# Altium schematic sheet retroBreadboard_v1i1.SchDoc
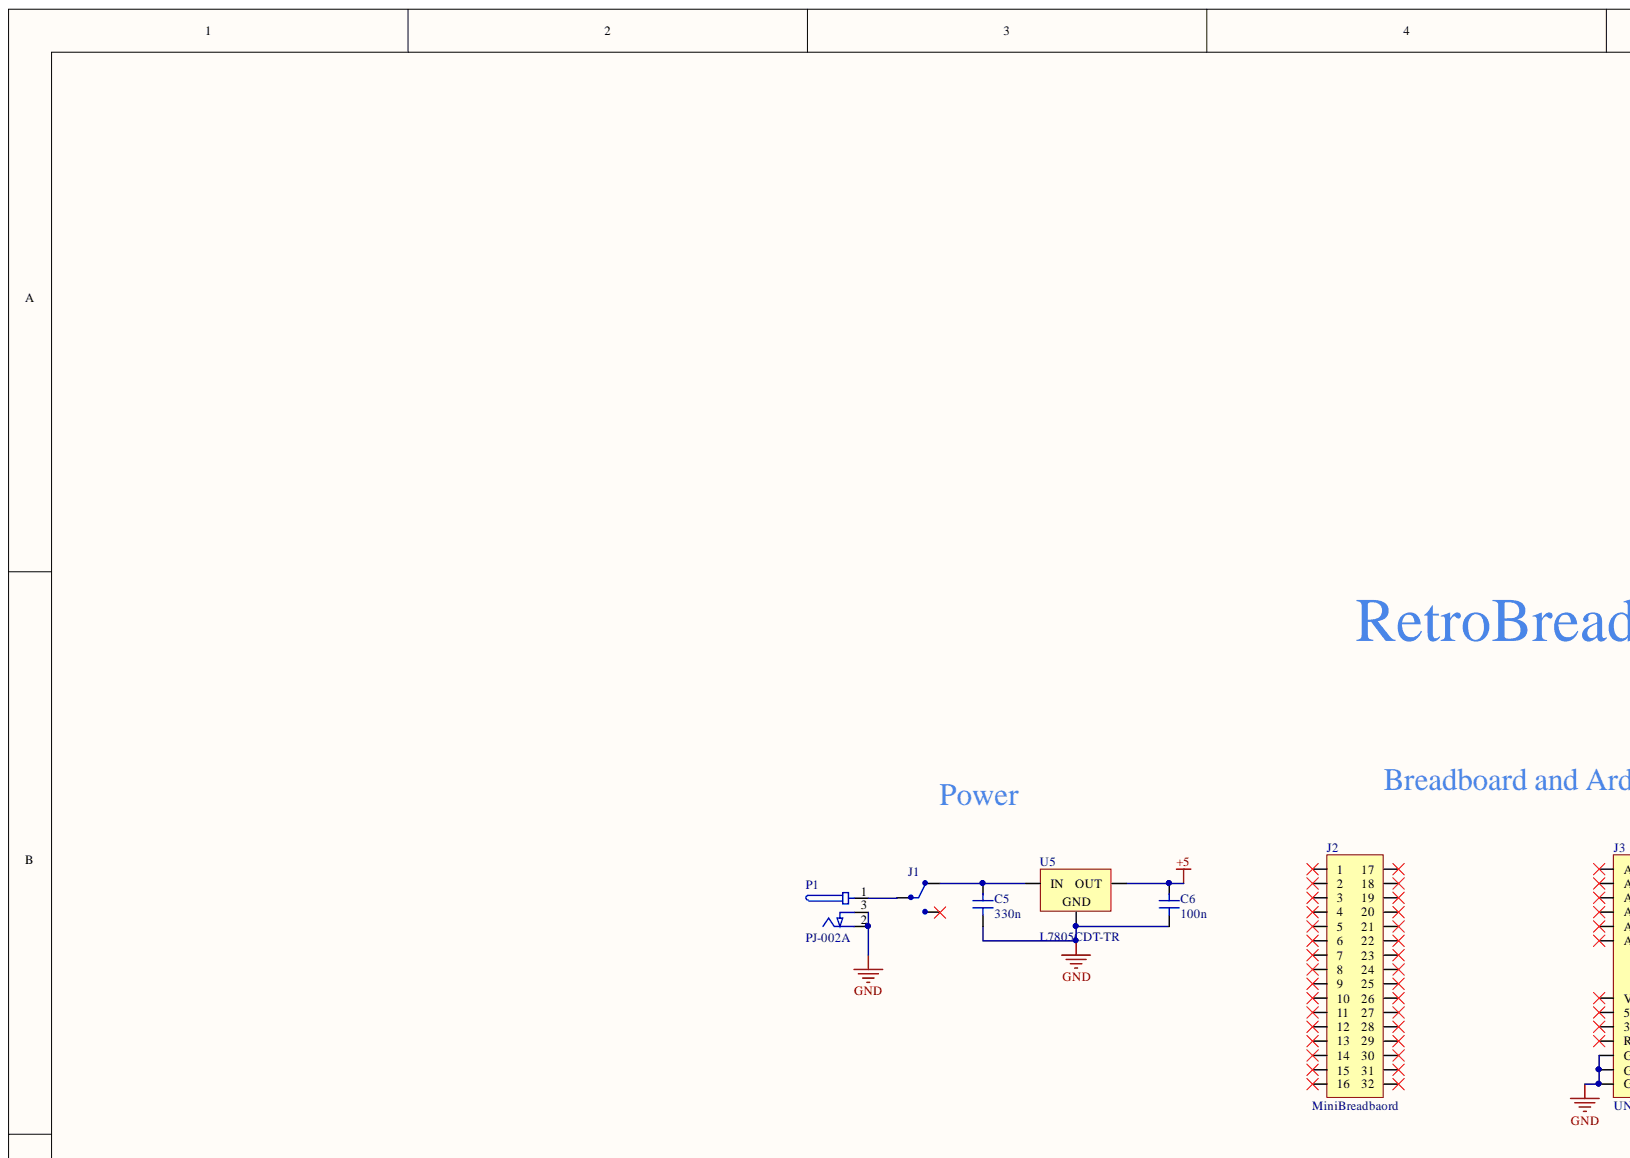
[diagram p0: retroBreadboard_v1i1.SchDoc, top left]
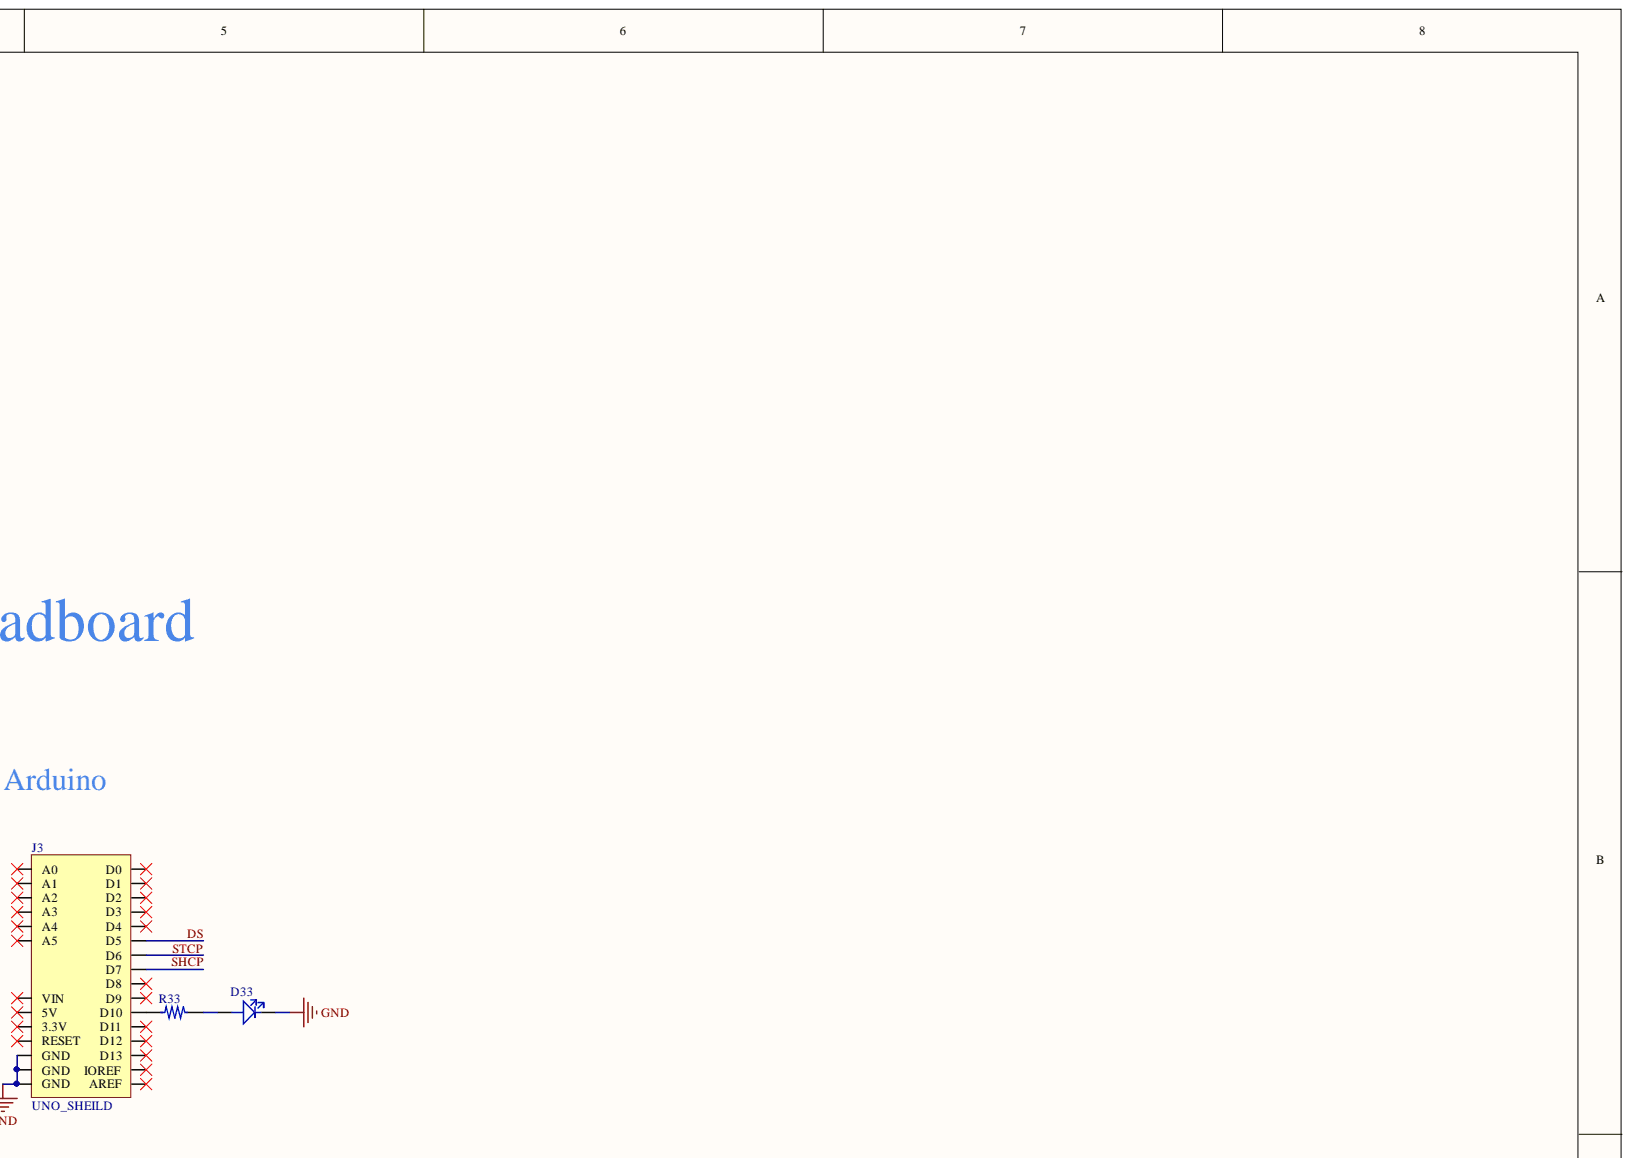
[diagram p1: retroBreadboard_v1i1.SchDoc, top right]
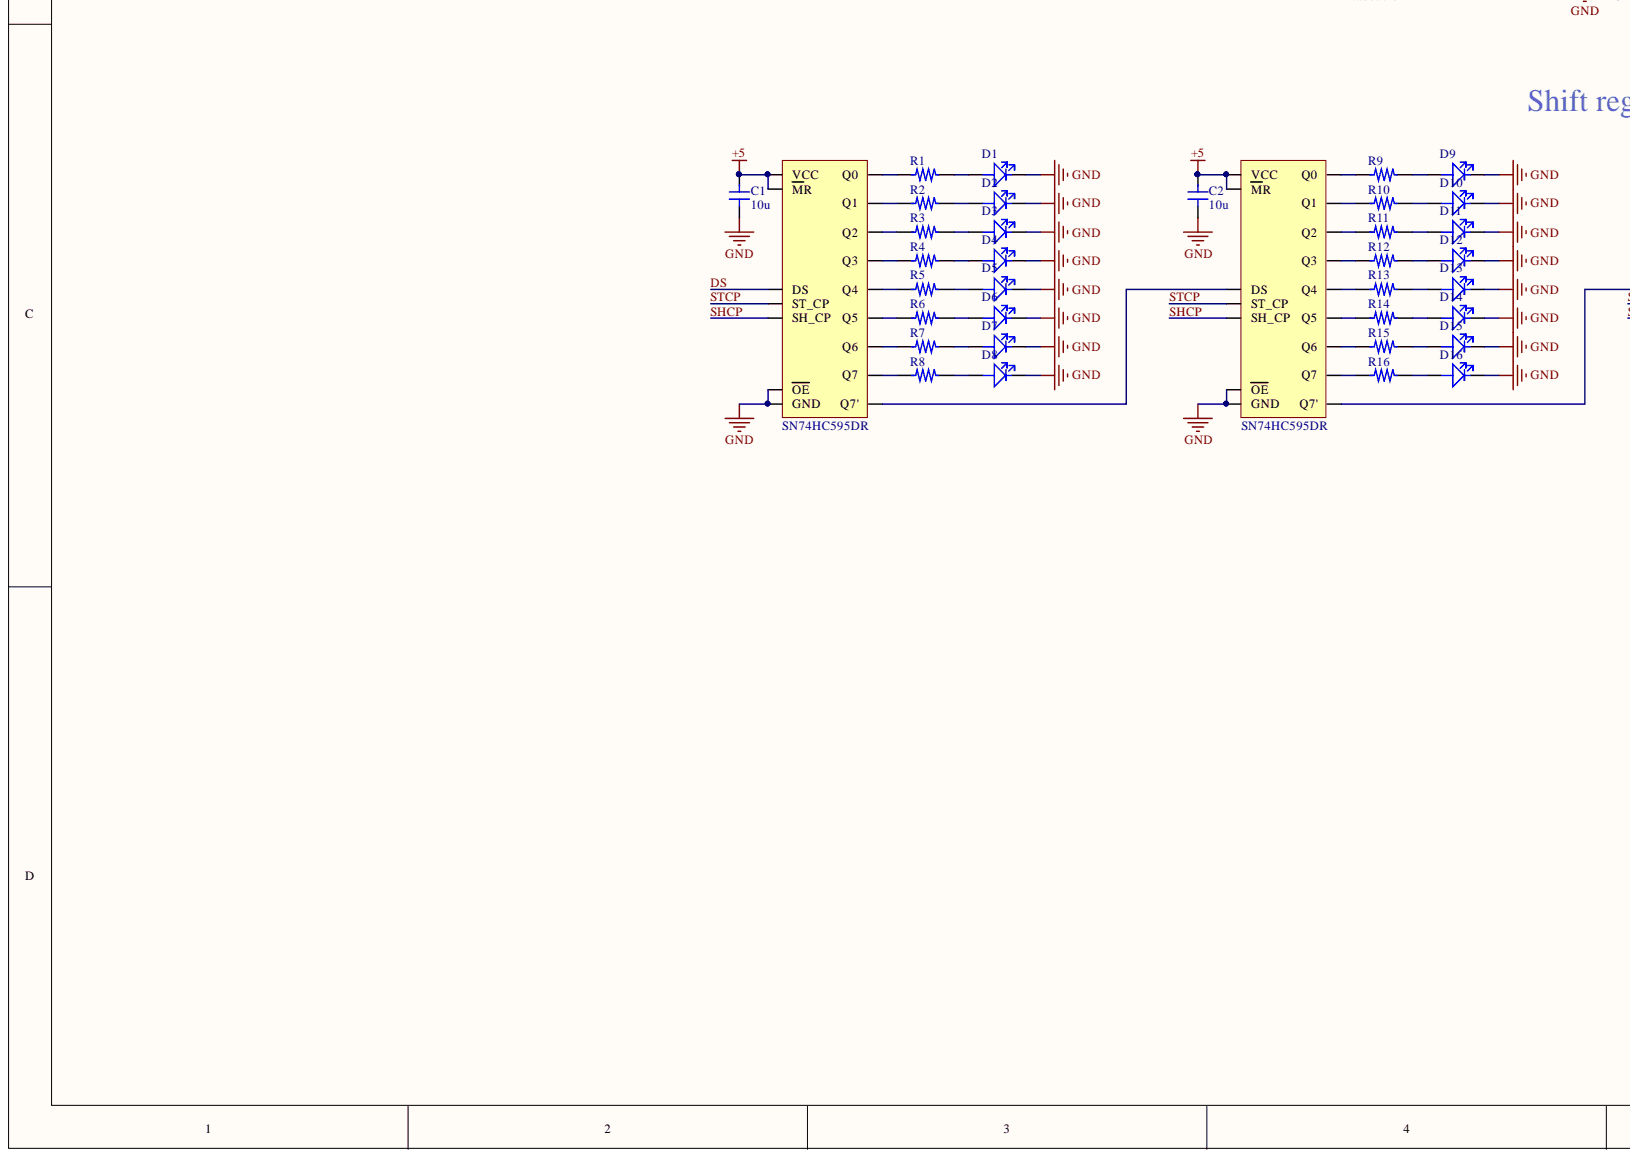
[diagram p2: retroBreadboard_v1i1.SchDoc, bottom left]
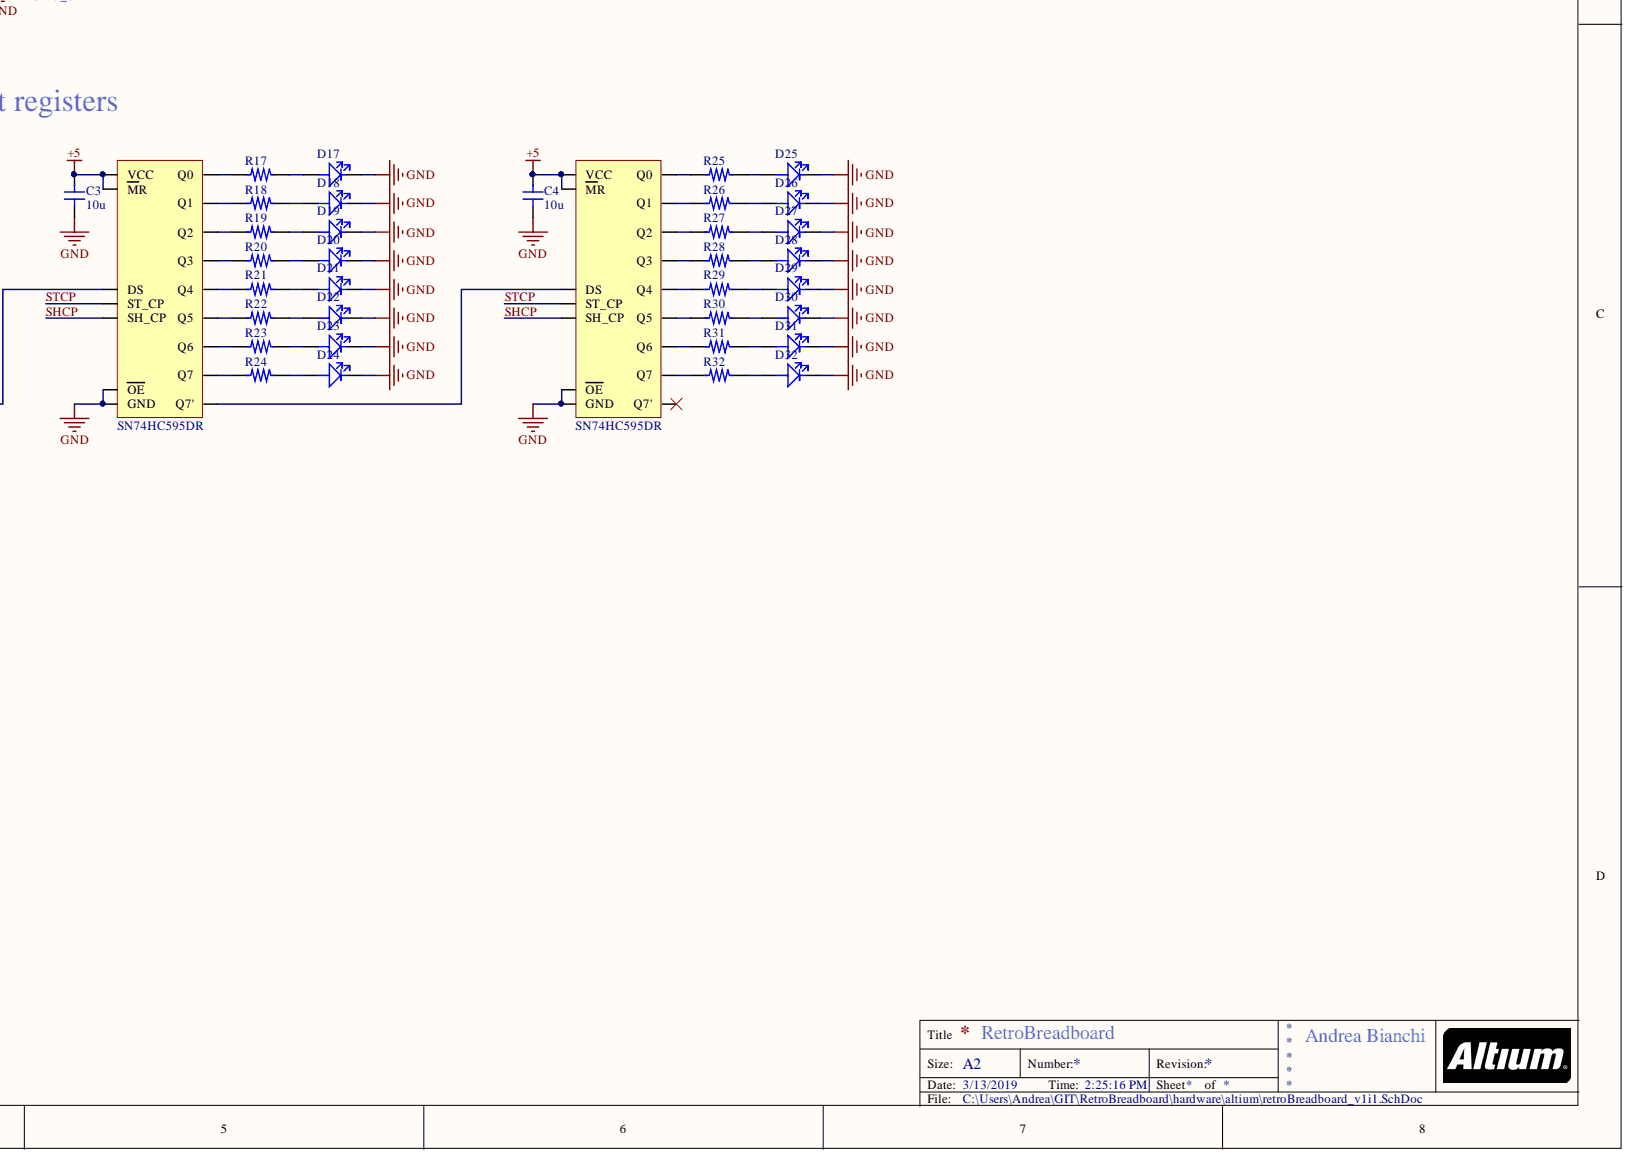
[diagram p3: retroBreadboard_v1i1.SchDoc, bottom right]

## Parts
C1  10u  [C0805]
C2  10u  [C0805]
C3  10u  [C0805]
C4  10u  [C0805]
C5  330n  [C0805]
C6  100n  [C0805]
D1  VLMB1500-GS08  [VLMB1500-GS08]  (value not drawn)
D2  VLMB1500-GS08  [VLMB1500-GS08]  (value not drawn)
D3  VLMB1500-GS08  [VLMB1500-GS08]  (value not drawn)
D4  VLMB1500-GS08  [VLMB1500-GS08]  (value not drawn)
D5  VLMB1500-GS08  [VLMB1500-GS08]  (value not drawn)
D6  VLMB1500-GS08  [VLMB1500-GS08]  (value not drawn)
D7  VLMB1500-GS08  [VLMB1500-GS08]  (value not drawn)
D8  VLMB1500-GS08  [VLMB1500-GS08]  (value not drawn)
D9  VLMB1500-GS08  [VLMB1500-GS08]  (value not drawn)
D10  VLMB1500-GS08  [VLMB1500-GS08]  (value not drawn)
D11  VLMB1500-GS08  [VLMB1500-GS08]  (value not drawn)
D12  VLMB1500-GS08  [VLMB1500-GS08]  (value not drawn)
D13  VLMB1500-GS08  [VLMB1500-GS08]  (value not drawn)
D14  VLMB1500-GS08  [VLMB1500-GS08]  (value not drawn)
D15  VLMB1500-GS08  [VLMB1500-GS08]  (value not drawn)
D16  VLMB1500-GS08  [VLMB1500-GS08]  (value not drawn)
D17  VLMB1500-GS08  [VLMB1500-GS08]  (value not drawn)
D18  VLMB1500-GS08  [VLMB1500-GS08]  (value not drawn)
D19  VLMB1500-GS08  [VLMB1500-GS08]  (value not drawn)
D20  VLMB1500-GS08  [VLMB1500-GS08]  (value not drawn)
D21  VLMB1500-GS08  [VLMB1500-GS08]  (value not drawn)
D22  VLMB1500-GS08  [VLMB1500-GS08]  (value not drawn)
D23  VLMB1500-GS08  [VLMB1500-GS08]  (value not drawn)
D24  VLMB1500-GS08  [VLMB1500-GS08]  (value not drawn)
D25  VLMB1500-GS08  [VLMB1500-GS08]  (value not drawn)
D26  VLMB1500-GS08  [VLMB1500-GS08]  (value not drawn)
D27  VLMB1500-GS08  [VLMB1500-GS08]  (value not drawn)
D28  VLMB1500-GS08  [VLMB1500-GS08]  (value not drawn)
D29  VLMB1500-GS08  [VLMB1500-GS08]  (value not drawn)
D30  VLMB1500-GS08  [VLMB1500-GS08]  (value not drawn)
D31  VLMB1500-GS08  [VLMB1500-GS08]  (value not drawn)
D32  VLMB1500-GS08  [VLMB1500-GS08]  (value not drawn)
D33  VLMB1500-GS08  [VLMB1500-GS08]  (value not drawn)
J1  500SSP1S1M6QEA  [SLIDE SPDT]  (value not drawn)
J2  MiniBreadbaord  [MINI_BREADBOARD HOLE]
J3  UNO_SHEILD  [ARDUINO_UNO_SHIELD]
P1  PJ-002A  [PJ-002A]
R1  RC0402FR-07200RL  [RES0402]  (value not drawn)
R2  RC0402FR-07200RL  [RES0402]  (value not drawn)
R3  RC0402FR-07200RL  [RES0402]  (value not drawn)
R4  RC0402FR-07200RL  [RES0402]  (value not drawn)
R5  RC0402FR-07200RL  [RES0402]  (value not drawn)
R6  RC0402FR-07200RL  [RES0402]  (value not drawn)
R7  RC0402FR-07200RL  [RES0402]  (value not drawn)
R8  RC0402FR-07200RL  [RES0402]  (value not drawn)
R9  RC0402FR-07200RL  [RES0402]  (value not drawn)
R10  RC0402FR-07200RL  [RES0402]  (value not drawn)
R11  RC0402FR-07200RL  [RES0402]  (value not drawn)
R12  RC0402FR-07200RL  [RES0402]  (value not drawn)
R13  RC0402FR-07200RL  [RES0402]  (value not drawn)
R14  RC0402FR-07200RL  [RES0402]  (value not drawn)
R15  RC0402FR-07200RL  [RES0402]  (value not drawn)
R16  RC0402FR-07200RL  [RES0402]  (value not drawn)
R17  RC0402FR-07200RL  [RES0402]  (value not drawn)
R18  RC0402FR-07200RL  [RES0402]  (value not drawn)
R19  RC0402FR-07200RL  [RES0402]  (value not drawn)
R20  RC0402FR-07200RL  [RES0402]  (value not drawn)
R21  RC0402FR-07200RL  [RES0402]  (value not drawn)
R22  RC0402FR-07200RL  [RES0402]  (value not drawn)
R23  RC0402FR-07200RL  [RES0402]  (value not drawn)
R24  RC0402FR-07200RL  [RES0402]  (value not drawn)
R25  RC0402FR-07200RL  [RES0402]  (value not drawn)
R26  RC0402FR-07200RL  [RES0402]  (value not drawn)
R27  RC0402FR-07200RL  [RES0402]  (value not drawn)
R28  RC0402FR-07200RL  [RES0402]  (value not drawn)
R29  RC0402FR-07200RL  [RES0402]  (value not drawn)
R30  RC0402FR-07200RL  [RES0402]  (value not drawn)
R31  RC0402FR-07200RL  [RES0402]  (value not drawn)
R32  RC0402FR-07200RL  [RES0402]  (value not drawn)
R33  RC0402FR-07200RL  [RES0402]  (value not drawn)
U1  SN74HC595DR  [SOIC16]
U2  SN74HC595DR  [SOIC16]
U3  SN74HC595DR  [SOIC16]
U4  SN74HC595DR  [SOIC16]
U5  L7805CDT-TR  [DPAK]

## Nets
+5: C1.2 C2.2 C3.2 C4.2 C6.2 U1.10(MR) U1.16(VCC) U2.10(MR) U2.16(VCC) U3.10(MR) U3.16(VCC) U4.10(MR) U4.16(VCC) U5.3
GND: C1.1 C2.1 C3.1 C4.1 C5.1 C6.1 D1.2 D2.2 D3.2 D4.2 D5.2 D6.2 D7.2 D8.2 D9.2 D10.2 D11.2 D12.2 D13.2 D14.2 D15.2 D16.2 D17.2 D18.2 D19.2 D20.2 D21.2 D22.2 D23.2 D24.2 D25.2 D26.2 D27.2 D28.2 D29.2 D30.2 D31.2 D32.2 D33.2 J3.15(GND) J3.24(GND) J3.25(GND) P1.2 P1.3 U1.8(GND) U1.13(OE) U2.8(GND) U2.13(OE) U3.8(GND) U3.13(OE) U4.8(GND) U4.13(OE) U5.4
DS: J3.6(D5) U1.14(DS)
SHCP: J3.8(D7) U1.11(SH_CP) U2.11(SH_CP) U3.11(SH_CP) U4.11(SH_CP)
STCP: J3.7(D6) U1.12(ST_CP) U2.12(ST_CP) U3.12(ST_CP) U4.12(ST_CP)
NetC5_2: C5.2 J1.1 U5.1
NetD1_1: D1.1 R1.2
NetD2_1: D2.1 R2.2
NetD3_1: D3.1 R3.2
NetD4_1: D4.1 R4.2
NetD5_1: D5.1 R5.2
NetD6_1: D6.1 R6.2
NetD7_1: D7.1 R7.2
NetD8_1: D8.1 R8.2
NetD9_1: D9.1 R9.2
NetD10_1: D10.1 R10.2
NetD11_1: D11.1 R11.2
NetD12_1: D12.1 R12.2
NetD13_1: D13.1 R13.2
NetD14_1: D14.1 R14.2
NetD15_1: D15.1 R15.2
NetD16_1: D16.1 R16.2
NetD17_1: D17.1 R17.2
NetD18_1: D18.1 R18.2
NetD19_1: D19.1 R19.2
NetD20_1: D20.1 R20.2
NetD21_1: D21.1 R21.2
NetD22_1: D22.1 R22.2
NetD23_1: D23.1 R23.2
NetD24_1: D24.1 R24.2
NetD25_1: D25.1 R25.2
NetD26_1: D26.1 R26.2
NetD27_1: D27.1 R27.2
NetD28_1: D28.1 R28.2
NetD29_1: D29.1 R29.2
NetD30_1: D30.1 R30.2
NetD31_1: D31.1 R31.2
NetD32_1: D32.1 R32.2
NetD33_1: D33.1 R33.2
NetJ1_2: J1.2 P1.1
NetJ3_11: J3.11(D10) R33.1
NetR1_1: R1.1 U1.15(Q0)
NetR2_1: R2.1 U1.1(Q1)
NetR3_1: R3.1 U1.2(Q2)
NetR4_1: R4.1 U1.3(Q3)
NetR5_1: R5.1 U1.4(Q4)
NetR6_1: R6.1 U1.5(Q5)
NetR7_1: R7.1 U1.6(Q6)
NetR8_1: R8.1 U1.7(Q7)
NetR9_1: R9.1 U2.15(Q0)
NetR10_1: R10.1 U2.1(Q1)
NetR11_1: R11.1 U2.2(Q2)
NetR12_1: R12.1 U2.3(Q3)
NetR13_1: R13.1 U2.4(Q4)
NetR14_1: R14.1 U2.5(Q5)
NetR15_1: R15.1 U2.6(Q6)
NetR16_1: R16.1 U2.7(Q7)
NetR17_1: R17.1 U3.15(Q0)
NetR18_1: R18.1 U3.1(Q1)
NetR19_1: R19.1 U3.2(Q2)
NetR20_1: R20.1 U3.3(Q3)
NetR21_1: R21.1 U3.4(Q4)
NetR22_1: R22.1 U3.5(Q5)
NetR23_1: R23.1 U3.6(Q6)
NetR24_1: R24.1 U3.7(Q7)
NetR25_1: R25.1 U4.15(Q0)
NetR26_1: R26.1 U4.1(Q1)
NetR27_1: R27.1 U4.2(Q2)
NetR28_1: R28.1 U4.3(Q3)
NetR29_1: R29.1 U4.4(Q4)
NetR30_1: R30.1 U4.5(Q5)
NetR31_1: R31.1 U4.6(Q6)
NetR32_1: R32.1 U4.7(Q7)
NetU1_9: U1.9(Q7') U2.14(DS)
NetU2_9: U2.9(Q7') U3.14(DS)
NetU3_9: U3.9(Q7') U4.14(DS)
NetU4_9: U4.9(Q7')

Not connected: J1 3; J2 1 2 3 4 5 6 7 8 9 10 11 12 13 14 15 16 17 18 19 20 21 22 23 24 25 26 27 28 29 30 31 32; J3 1(D0) 2(D1) 3(D2) 4(D3) 5(D4) 9(D8) 10(D9) 12(D11) 13(D12) 14(D13) 16(AREF) 17(A5) 18(A4) 19(A3) 20(A2) 21(A1) 22(A0) 23(VIN) 26(5V) 27(3.3V) 28(RESET) 29(IOREF)

## Library data of the parts
C1-C4 = C0805_10u: Manufacturer: Samsung; Manufacturer Part Number: CL21A106KQFNNNG; Capacitance: 10uF; Dielectric: X5R; Material: Ceramic; Tolerance: 10%; Voltage: 6.3V; Case/Package: 0805; Case Code (Imperial): 0805; Case Code (Metric): 2012; Component Kind: Standard; ComponentLink1Description: http://www.samsungsem.com/kr/support/product-search/mlcc/CL21A106KQFNNNG.jsp?src-supplier=Digi-Key; ComponentLink1URL: http://www.samsungsem.com/kr/support/product-search/mlcc/CL21A106KQFNNNG.jsp?src-supplier=Digi-Key; ComponentLink2Description: https://produktinfo.conrad.com/datenblaetter/450000-474999/454985-da-01-en-VS_KONDENSATOR_0805.pdf?src-supplier=Conrad; ComponentLink2URL: https://produktinfo.conrad.com/datenblaetter/450000-474999/454985-da-01-en-VS_KONDENSATOR_0805.pdf?src-supplier=Conrad; Depth: 1.25mm; Description: 10u; Height: 1.25mm; Lead Free: Lead Free; Length: 2mm; Max Operating Temperature: 85°C; Min Operating Temperature: -55°C; Mount: Surface Mount; Package Quantity: 3000; Packaging: Tape and Reel; Pricing 1: 1 = 0.13000, 10 = 0.09600, 100 = 0.04270, 500 = 0.03054, 1000 = 0.02399 (USD); RoHS: Yes; Stock 1: 53378; Supplier 1: Digi-Key; Supplier Part Number 1: 1276-6457-1-ND; Thickness: 0.053inch; Voltage Rating: 6.3V; Width: 0.049inch; Library Name: retroBreadboard_v1i1.SchLib
C5 = C0805_330n: Manufacturer: Samsung; Manufacturer Part Number: CL21B334KAFNNNG; Capacitance: 330nF; Dielectric: X7R; Material: Ceramic; Tolerance: 10%; Voltage: 25V; Case/Package: 0805; Case Code (Imperial): 0805; Case Code (Metric): 2012; Component Kind: Standard; ComponentLink1Description: https://www.distrelec.biz/Web/Downloads/_e/ng/MLCC_CatalogDownload2013_ENG.pdf?mime=application%2Fpdf&src-supplier=Distrelec; ComponentLink1URL: https://www.distrelec.biz/Web/Downloads/_e/ng/MLCC_CatalogDownload2013_ENG.pdf?mime=application%2Fpdf&src-supplier=Distrelec; ComponentLink2Description: http://www.samsungsem.com/global/support/library/product-catalog/__icsFiles/afieldfile/2014/11/13/MLCC.pdf?src-supplier=Digi-Key; ComponentLink2URL: http://www.samsungsem.com/global/support/library/product-catalog/__icsFiles/afieldfile/2014/11/13/MLCC.pdf?src-supplier=Digi-Key; ComponentLink3Description: http://www1.futureelectronics.com/doc/SAMSUNG%20PASSIVE/CL05B104KQ5NNNC.pdf?src-supplier=Future+Electronics; ComponentLink3URL: http://www1.futureelectronics.com/doc/SAMSUNG%20PASSIVE/CL05B104KQ5NNNC.pdf?src-supplier=Future+Electronics; Depth: 1.25mm; Description: 330n; Height: 1.25mm; Lead Free: Lead Free; Length: 2mm; Max Operating Temperature: 125°C; Min Operating Temperature: -55°C; Mount: Surface Mount; Package Quantity: 3000; Packaging: Tape and Reel; Pricing 1: 1 = 0.12000, 10 = 0.08600, 100 = 0.03810, 500 = 0.02722, 1000 = 0.02138 (USD); RoHS: Yes; Stock 1: 834; Supplier 1: Digi-Key; Supplier Part Number 1: 1276-6479-1-ND; Thickness: 0.053inch; Voltage Rating: 25V; Width: 0.049inch; Library Name: retroBreadboard_v1i1.SchLib
C6 = C0805_100n: Manufacturer: Samsung; Manufacturer Part Number: CL21F104ZBCNNNC; Capacitance: 100nF; Dielectric: Y5V; Material: Ceramic; Tolerance: 80%; Voltage Rating: 50V; Case/Package: 0805; Case Code (Imperial): 0805; Case Code (Metric): 2012; Component Kind: Standard; ComponentLink1Description: https://www.verical.com/server-webapp/html/getDatasheet?partID=466953&src-supplier=Verical; ComponentLink1URL: https://www.verical.com/server-webapp/html/getDatasheet?partID=466953&src-supplier=Verical; ComponentLink2Description: https://produktinfo.conrad.com/datenblaetter/450000-474999/454191-da-01-en-VS_KONDENSATOR_0805.pdf?src-supplier=Conrad; ComponentLink2URL: https://produktinfo.conrad.com/datenblaetter/450000-474999/454191-da-01-en-VS_KONDENSATOR_0805.pdf?src-supplier=Conrad; Depth: 1.25mm; Description: 100n; Height: 0.85mm; Length: 2mm; Max Operating Temperature: 85°C; Min Operating Temperature: -30°C; Mount: Surface Mount; Package Quantity: 1; Packaging: Tape and Reel; Pricing 1: 1 = 0.10000, 10 = 0.04400, 100 = 0.01950, 500 = 0.01390, 1000 = 0.01092 (USD); Radiation Hardening: No; RoHS: Yes; Stock 1: 117334; Supplier 1: Digi-Key; Supplier Part Number 1: 1276-1007-1-ND; Thickness: 0.037inch; Width: 0.049inch; Library Name: retroBreadboard_v1i1.SchLib
D1-D33 = VLMB1500-GS08: Standard LEDs - SMD 0402 BLUE Non-Diff; Manufacturer: Vishay; Dominant Wavelength: 470nm; Forward Current: 30mA; Forward Voltage: 3.15V; Lens Colour: Clear; Lens Style: Rectangular; Luminous Intensity: 45mcd; Max Power Dissipation: 76mW; Number of Elements: 1; Power Rating: 75mW; Test Current: 5mA; Viewing Angle: 130°; Case/Package: 0402; Case Code (Imperial): 0402; Case Code (Metric): 1005; Colour: Blue; Component Kind: Standard; ComponentLink1Description: http://www.vishay.com/docs/82554/vlmo1500.pdf; ComponentLink1URL: http://www.vishay.com/docs/82554/vlmo1500.pdf; Contact Plating: Matte,Tin; Depth: 0.5mm; Height: 0.35mm; Illumination Colour: Blue; Lead Free: Lead Free; Length: 1mm; Manufacturer Part Number: VLMB1500-GS08; Max Operating Temperature: 80°C; Min Operating Temperature: -30°C; Mount: Surface Mount; Number of LEDs: 1; Number of Pins: 2; Package Quantity: 1; Packaging: Tape and Reel; Power Dissipation: 76mW; Pricing 1: 1=0.41, 10=0.292, 25=0.2532, 100=0.1949, 250=0.15836, 500=0.11696, 1000=0.09746 (USD); Radiation Hardening: No; REACH SVHC: Unknown; Stock 1: 322508; Supplier 1: Digi-Key; Supplier Part Number 1: VLMB1500-GS08DKR-ND; Width: 0.5mm; Library Name: retroBreadboard_v1i1.SchLib
J1 = SLIDE SPDT: SWITCH SLIDE SPDT 5A 120V; Manufacturer: E-Switch; Actuator Length: 4.72mm; Actuator Material: Nylon,Polyamide; Contact Resistance: 10mR; Electrical Life: 30000Cycles; Max Voltage Rating (AC): 250V; Max Voltage Rating (DC): 28V; Switch Function: On-On; Switch Travel: 2.16mm; Component Kind: Standard; ComponentLink1Description: https://datasheet.ciiva.com/16337/500-16337624.pdf; ComponentLink1URL: https://datasheet.ciiva.com/16337/500-16337624.pdf; ComponentLink2Description: https://datasheet.ciiva.com/16174/35500-16174234.pdf; ComponentLink2URL: https://datasheet.ciiva.com/16174/35500-16174234.pdf; ComponentLink3Description: https://datasheet.ciiva.com/2889/t511006d-2889419.pdf; ComponentLink3URL: https://datasheet.ciiva.com/2889/t511006d-2889419.pdf; Contact Current Rating: 5A; Contact Material: Copper,Silver,Copper Alloy; Contact Plating: Silver; Current Rating: 5A; Flammability Rating: UL94 V-0; Housing Material: Stainless Steel; Lead Free: Lead Free; Manufacturer Part Number: 500SSP1S1M6QEA; Max Operating Temperature: 85°C; Mechanical Life: 30000Cycles; Min Operating Temperature: -30°C; Mount: Through Hole,Brackets; Orientation: Right Angle; Package Quantity: 1; Packaging: Bulk; Pricing 1: 1=2.93, 10=2.808, 25=2.7484, 50=2.39, 100=2.3303, 250=2.27052, 500=1.912, 1000=1.7925, 5000=1.64313 (USD); REACH SVHC: Unknown; Stock 1: 6111; Supplier 1: Digi-Key; Supplier Part Number 1: EG2478-ND; Termination: PC Pin; Throw Configuration: SPDT; Voltage Rating (AC): 120V; Voltage Rating (DC): 28V; Library Name: retroBreadboard_v1i1.SchLib
J2 = MINI_BREADBOARD: Mini Breadboard; Manufacturer: TE Connectivity AMP; Manufacturer Part Number: 1738132-1; Gender: Male; Colour: Natural; Component Kind: Standard; ComponentLink1Description: http://www.te.com/commerce/DocumentDelivery/DDEController?Action=srchrtrv&DocNm=1738132&DocType=Customer+Drawing&DocLang=English; ComponentLink1URL: http://www.te.com/commerce/DocumentDelivery/DDEController?Action=srchrtrv&DocNm=1738132&DocType=Customer+Drawing&DocLang=English; Stock 1: 0; Supplier 1: Digi-Key; Supplier Part Number 1: 1738132-1-ND; Library Name: retroBreadboard_v1i1.SchLib
J3 = ARDUINO_UNO_SHIELD: Component Kind: Standard; Library Name: retroBreadboard_v1i1.SchLib
P1 = PJ-002A: PJ-002 Series 2 x 6.5 mm 16 V Right Angle Through Hole Power Jack; Manufacturer: CUI; Current Rating: 2.5A; Gender: Male; Insulation Resistance: 50MR; Number of Conductors: 2; Shielding: Unshielded; Voltage Rating: 24V; Colour: Black; Component Kind: Standard; ComponentLink1Description: http://www.cui.com/product/resource/digikeypdf/pj-002a.pdf; ComponentLink1URL: http://www.cui.com/product/resource/digikeypdf/pj-002a.pdf; ComponentLink2Description: http://www.cui.com/Product/Resource/FuturePDF/PJ-002A.pdf; ComponentLink2URL: http://www.cui.com/Product/Resource/FuturePDF/PJ-002A.pdf; ComponentLink3Description: http://www.mouser.com/ds/2/670/pj-002a-515880.pdf; ComponentLink3URL: http://www.mouser.com/ds/2/670/pj-002a-515880.pdf; Connector Type: Jack; Contact Gender: Male; Contact Material: Copper,Copper Alloy; Contact Plating: Nickel,Tin; Diameter: 2.1mm; Flammability Rating: UL94 V-0; Housing Colour: Black; Housing Material: Thermoplastic; Lead Free: Lead Free; Manufacturer Part Number: PJ-002A; Max Operating Temperature: 85°C; Min Operating Temperature: -25°C; Mount: Through Hole; Orientation: Horizontal; Package Quantity: 500; Packaging: Bulk; Stock 1: 133077; Supplier 1: Digi-Key; Supplier Part Number 1: CP-002A-ND; Termination: Through Hole; Library Name: retroBreadboard_v1i1.SchLib
R1-R33 = R0402_200: RES SMD 200 OHM 1% 1/16W 0402; Manufacturer: Yageo; Max Power Dissipation: 63mW; Resistance: 200R; Temperature Coefficient: 100ppm/°C; Voltage Rating: 50V; Case/Package: 0402; Case Code (Imperial): 0402; Case Code (Metric): 1005; Component Kind: Standard; ComponentLink1Description: http://www.yageo.com/documents/recent/PYu-RC_Group_51_RoHS_L_10.pdf?src-supplier=Digi-Key; ComponentLink1URL: http://www.yageo.com/documents/recent/PYu-RC_Group_51_RoHS_L_10.pdf?src-supplier=Digi-Key; ComponentLink2Description: http://www.farnell.com/datasheets/1018594.pdf?src-supplier=Element14; ComponentLink2URL: http://www.farnell.com/datasheets/1018594.pdf?src-supplier=Element14; ComponentLink3Description: http://www.yageo.com/documents/recent/PYu-RC0402_51_RoHS_L_6_r.pdf?src-supplier=Future+Electronics; ComponentLink3URL: http://www.yageo.com/documents/recent/PYu-RC0402_51_RoHS_L_6_r.pdf?src-supplier=Future+Electronics; ComponentLink4Description: https://www.verical.com/server-webapp/html/getDatasheet?partID=331152&src-supplier=Verical; ComponentLink4URL: https://www.verical.com/server-webapp/html/getDatasheet?partID=331152&src-supplier=Verical; ComponentLink5Description: http://www.farnell.com/datasheets/2167052.pdf?src-supplier=Element14; ComponentLink5URL: http://www.farnell.com/datasheets/2167052.pdf?src-supplier=Element14; ComponentLink6Description: http://www.yageo.com/documents/recent/PYu-RC0402_51_RoHS_L_6_r.pdf?src-supplier=Mouser; ComponentLink6URL: http://www.yageo.com/documents/recent/PYu-RC0402_51_RoHS_L_6_r.pdf?src-supplier=Mouser; Composition: Thick Film; Depth: 0.5mm; Features: Moisture Resistant; Height: 0.016inch; Lead Free: Lead Free; Length: 1mm; Manufacturer Part Number: RC0402FR-07200RL; Max Operating Temperature: 155°C; Military Standard: Not; Min Operating Temperature: -55°C; Number of Terminations: 2; Package Quantity: 1; Packaging: Tape and Reel; Power Rating: 63mW; Pricing 1: 1 = 0.10000, 10 = 0.01600, 100 = 0.00670, 1000 = 0.00301, 2500 = 0.00261, 5000 = 0.00215 (USD); REACH SVHC: No SVHC; RoHS: Yes; Stock 1: 7546584; Supplier 1: Digi-Key; Supplier Part Number 1: 311-200LRCT-ND; Termination: SMD/SMT; Tolerance: 1%; Voltage Rating (DC): 50V; Width: 0.02inch; Library Name: retroBreadboard_v1i1.SchLib
U1-U4 = 74HC595: IC, SM, LOGIC, 74HC, REGISTER; Manufacturer: Texas Instruments; Direction: Unidirectional; Frequency: 42MHz; Logic Function: Shift Register; Max Input Current: 1uA; Max Supply Voltage: 6V; Min Supply Voltage: 2V; Number of Bits: 8; Number of Circuits: 4; Number of Elements: 1; Number of Output Lines: 10; Operating Supply Voltage: 5V; Propagation Delay: 250ns; Quiescent Current: 8uA; Case/Package: SOIC; Component Kind: Standard; ComponentLink1Description: http://www.ti.com/lit/ds/symlink/sn74hc595.pdf; ComponentLink1URL: http://www.ti.com/lit/ds/symlink/sn74hc595.pdf; ComponentLink2Description: http://www.farnell.com/datasheets/1831198.pdf; ComponentLink2URL: http://www.farnell.com/datasheets/1831198.pdf; ComponentLink3Description: http://www.farnell.com/datasheets/1886142.pdf; ComponentLink3URL: http://www.farnell.com/datasheets/1886142.pdf; ComponentLink4Description: https://www.verical.com/server-webapp/html/getDatasheet?partID=134875; ComponentLink4URL: https://www.verical.com/server-webapp/html/getDatasheet?partID=134875; ComponentLink5Description: http://www.farnell.com/datasheets/1905810.pdf; ComponentLink5URL: http://www.farnell.com/datasheets/1905810.pdf; ComponentLink6Description: http://www.ti.com/general/docs/lit/getliterature.tsp?genericPartNumber=SN54HC595-SP&&fileType=pdf; ComponentLink6URL: http://www.ti.com/general/docs/lit/getliterature.tsp?genericPartNumber=SN54HC595-SP&&fileType=pdf; ComponentLink7Description: http://www.jameco.com/Jameco/Products/ProdDS/916385.pdf; ComponentLink7URL: http://www.jameco.com/Jameco/Products/ProdDS/916385.pdf; ComponentLink8Description: http://www.farnell.com/datasheets/2020588.pdf; ComponentLink8URL: http://www.farnell.com/datasheets/2020588.pdf; ComponentLink9Description: http://datasheet.iiic.cc/texas_instruments/SN74HC595DR.pdf; ComponentLink9URL: http://datasheet.iiic.cc/texas_instruments/SN74HC595DR.pdf; Contact Plating: Gold; Height: 1.58mm; Lead Free: Lead Free; Length: 9.9mm; Manufacturer Part Number: SN74HC595DR; Max Operating Temperature: 85°C; Min Operating Temperature: -40°C; Mount: Surface Mount; Number of Bits per Element: 8; Number of Pins: 16; Package Quantity: 2500; Packaging: Tape and Reel; Pricing 1: 1=0.51, 10=0.433, 100=0.323, 500=0.2538, 1000=0.19611 (USD); Radiation Hardening: No; REACH SVHC: No SVHC; Stock 1: 53061; Supplier 1: Digi-Key; Supplier Part Number 1: 296-14857-6-ND; Termination: SMD/SMT; Turn-On Delay Time: 250ns; Weight: 0.004998oz; Width: 3.91mm; Library Name: retroBreadboard_v1i1.SchLib
U5 = L7805CDT-TR: IC REG LDO 5V 1.5A DPAK; Manufacturer: STMicroelectronics; Dropout Voltage: 2V; Max Input Voltage: 35V; Min Input Voltage: 7V; Number of Outputs: 1; Polarity: Positive; Power Supply Rejection Ratio (PSRR): 62dB; Quiescent Current: 8mA; Case/Package: TO-252; Component Kind: Standard; ComponentLink1Description: https://datasheet.ciiva.com/2042/cd00000444-2042784.pdf; ComponentLink1URL: https://datasheet.ciiva.com/2042/cd00000444-2042784.pdf; ComponentLink2Description: https://datasheet.ciiva.com/2042/cd00000444-2042785.pdf; ComponentLink2URL: https://datasheet.ciiva.com/2042/cd00000444-2042785.pdf; ComponentLink3Description: https://datasheet.ciiva.com/16357/cd00000444-16357893.pdf; ComponentLink3URL: https://datasheet.ciiva.com/16357/cd00000444-16357893.pdf; ComponentLink4Description: https://datasheet.ciiva.com/4882/cd00000444-4882231.pdf; ComponentLink4URL: https://datasheet.ciiva.com/4882/cd00000444-4882231.pdf; ComponentLink5Description: https://datasheet.ciiva.com/17360/cd00000444-17360220.pdf; ComponentLink5URL: https://datasheet.ciiva.com/17360/cd00000444-17360220.pdf; ComponentLink6Description: https://datasheet.ciiva.com/2042/cd00000444-2042783.pdf; ComponentLink6URL: https://datasheet.ciiva.com/2042/cd00000444-2042783.pdf; ComponentLink7Description: https://datasheet.ciiva.com/26904/l7805cdt-tr-26904768.pdf; ComponentLink7URL: https://datasheet.ciiva.com/26904/l7805cdt-tr-26904768.pdf; Contact Plating: Tin,Matte; Height: 2.4mm; Lead Free: Lead Free; Length: 6.6mm; Manufacturer Part Number: L7805CDT-TR; Max Operating Temperature: 125°C; Max Output Current: 1.5A; Min Operating Temperature: 0°C; Min Output Voltage: 5V; Mount: Surface Mount; Number of Pins: 3; Number of Regulators: 1; Output Current: 1.5A; Output Voltage: 5V; Package Quantity: 1; Packaging: Tape and Reel; Radiation Hardening: No; Stock 1: 7500; Supplier 1: Digi-Key; Supplier Part Number 1: 497-7255-2-ND; Width: 6.2mm; Library Name: retroBreadboard_v1i1.SchLib
